# Altium schematic sheet Sheet2.SchDoc
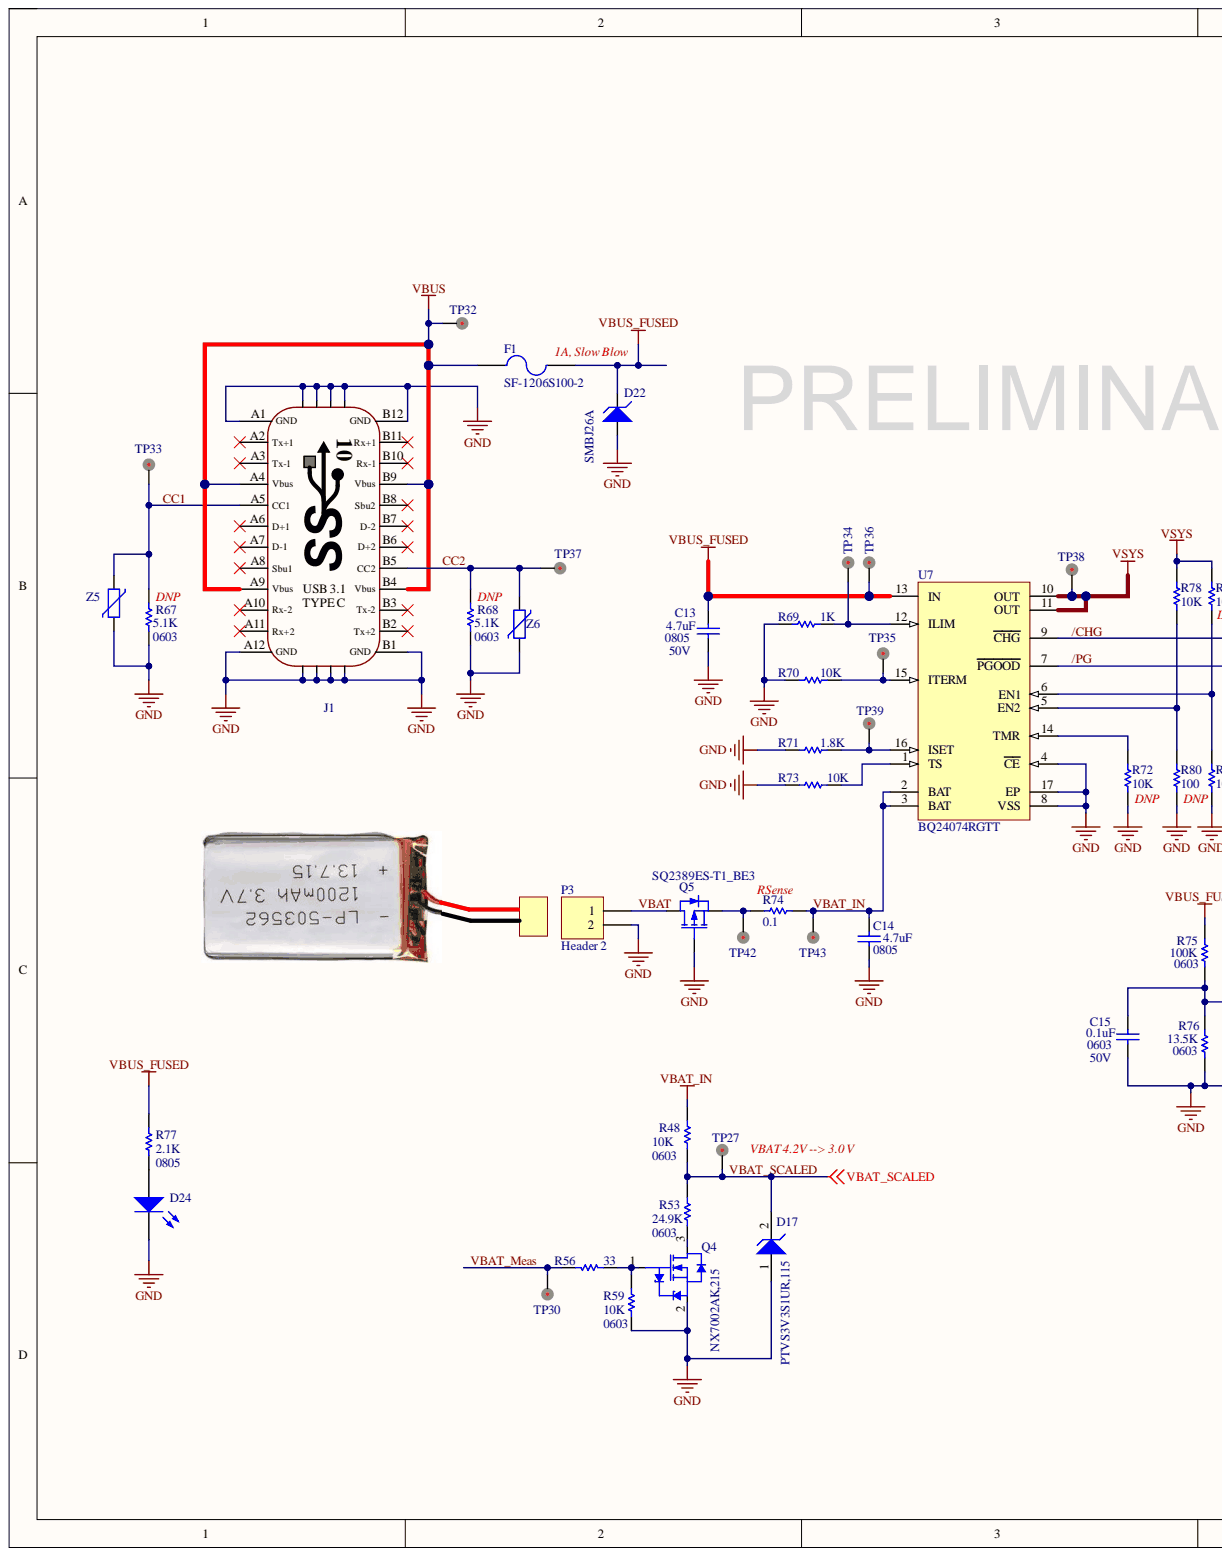
[diagram p0: Sheet2.SchDoc, left]
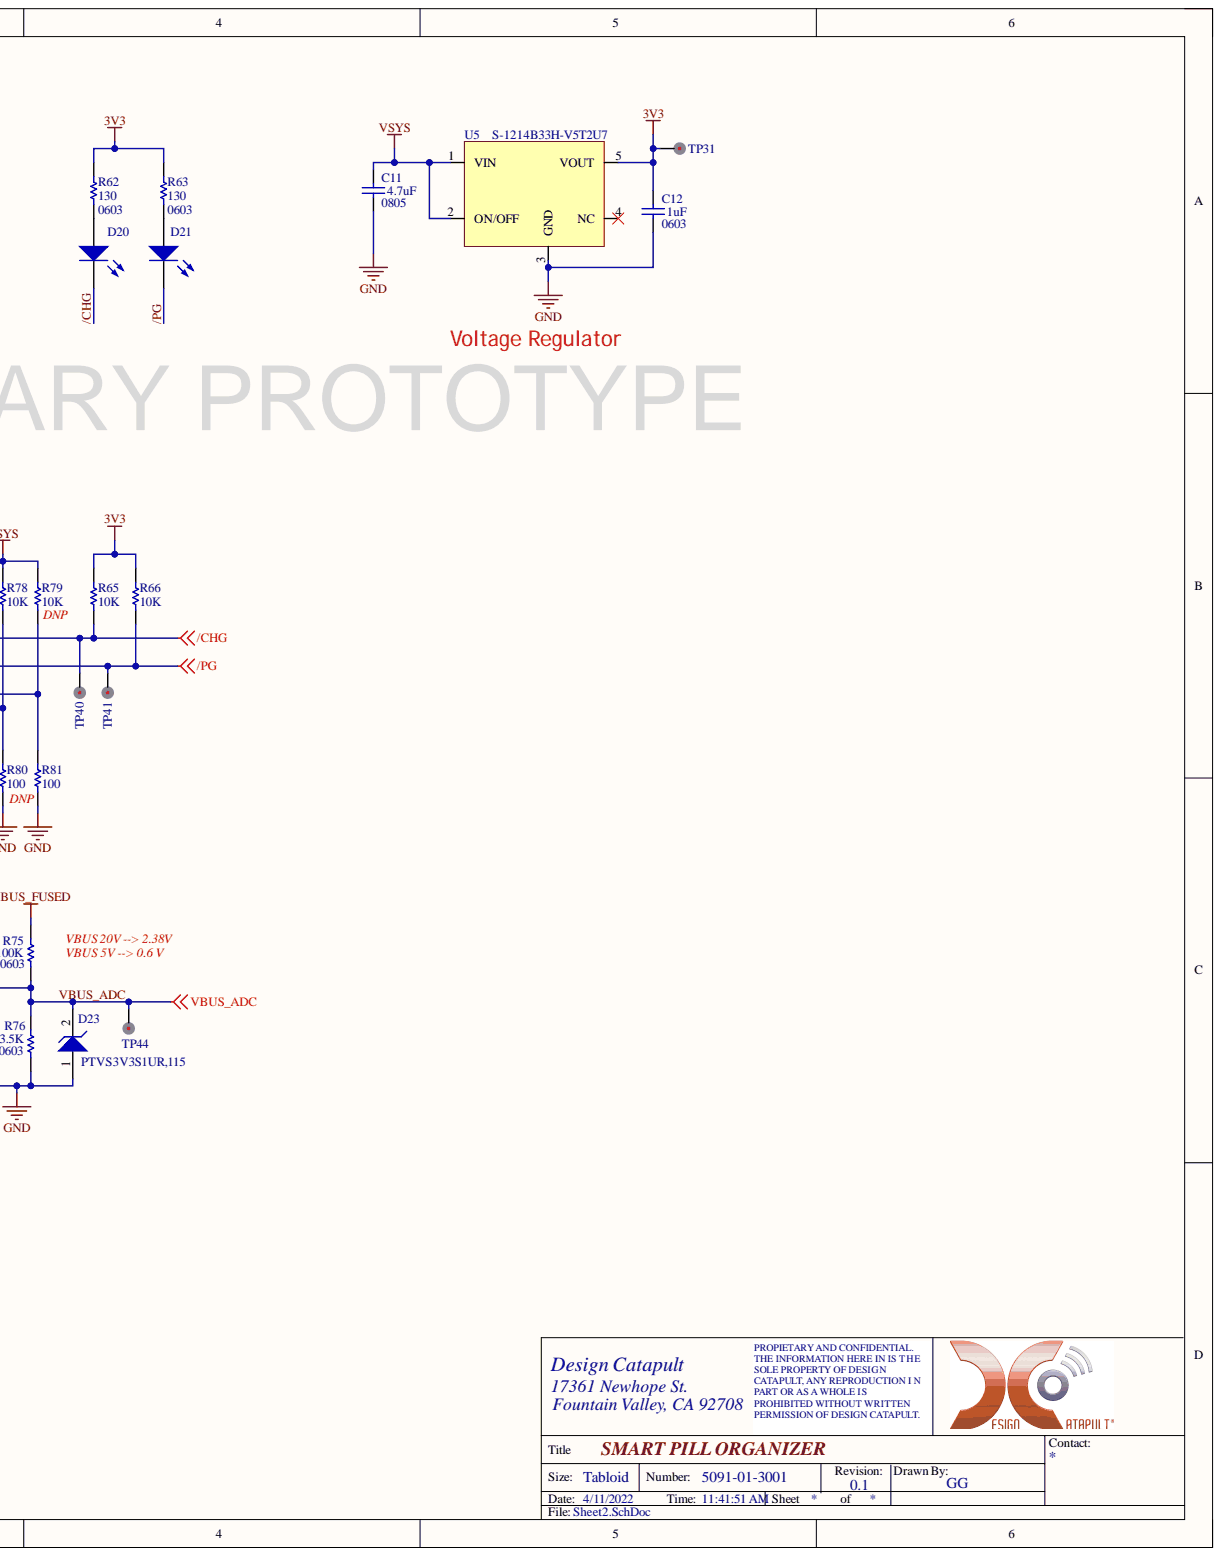
[diagram p1: Sheet2.SchDoc, right]

## Parts
C11  value 4.7uF  Package: 0805  [CAPC2012M]
C12  value 1uF  Package: 0603  [0603C]
C13  value 4.7uF  Package: 0805  Voltage: 50V  [CAPC2012M]
C14  4.7uF  Package: 0805  [CAPC2012M]
C15  0.1uF  Package: 0603  Voltage: 50V  [CAPC1608X90N]
D17  PTVS3V3S1UR,115  [SOD123]
D20  LED0603  [LED-0603-GREEN]  (value not drawn)
D21  LED0603  [LED-0603-GREEN]  (value not drawn)
D22  SMBJ26A  [VISH-DO-214AA-A-2_V]
D23  PTVS3V3S1UR,115  [SOD123]
D24  LED0603  [LED-0603-GREEN]  (value not drawn)
F1  SF-1206S100-2  [FUSE1206]
J1  USB_3.1_TypeC_Receptacle_1.6mm  [USB3 C - SMT ET T - H - F - WURTH - 632723X00011]  (value not drawn)
P3  Header 2  [HDR1X2]
Q4  NX7002AK,215  [SOT23-3N]
Q5  SQ2389ES-T1_BE3  [SOT23-3N]
R48  10K  Package: 0603  [0603R]
R53  24.9K  Package: 0603  [0603R]
R56  33  [0603R]
R59  10K  Package: 0603  [0603R]
R62  130  Package: 0603  [0603R]
R63  130  Package: 0603  [0603R]
R65  10K  [0603R]
R66  10K  [0603R]
R67  5.1K  Package: 0603  [0603R]
R68  5.1K  Package: 0603  [0603R]
R69  1K  [0603R]
R70  10K  [0603R]
R71  1.8K  [0603R]
R72  10K  [0603R]
R73  10K  [0603R]
R74  0.1  [RESC2012X08N]
R75  100K  Package: 0603  [0603R]
R76  13.5K  Package: 0603  [0603R]
R77  2.1K  [RESC2012X08N]
R78  10K  [0603R]
R79  10K  [0603R]
R80  100  [0603R]
R81  100  [0603R]
TP27  Pogo Pin  [TP-TH-PogoPin]  (value not drawn)
TP30  Pogo Pin  [TP-TH-PogoPin]  (value not drawn)
TP31  Pogo Pin  [TP-TH-PogoPin]  (value not drawn)
TP32  Pogo Pin  [TP-TH-PogoPin]  (value not drawn)
TP33  Pogo Pin  [TP-TH-PogoPin]  (value not drawn)
TP34  Pogo Pin  [TP-TH-PogoPin]  (value not drawn)
TP35  Pogo Pin  [TP-TH-PogoPin]  (value not drawn)
TP36  Pogo Pin  [TP-TH-PogoPin]  (value not drawn)
TP37  Pogo Pin  [TP-TH-PogoPin]  (value not drawn)
TP38  Pogo Pin  [TP-TH-PogoPin]  (value not drawn)
TP39  Pogo Pin  [TP-TH-PogoPin]  (value not drawn)
TP40  Pogo Pin  [TP-TH-PogoPin]  (value not drawn)
TP41  Pogo Pin  [TP-TH-PogoPin]  (value not drawn)
TP42  Pogo Pin  [TP-TH-PogoPin]  (value not drawn)
TP43  Pogo Pin  [TP-TH-PogoPin]  (value not drawn)
TP44  Pogo Pin  [TP-TH-PogoPin]  (value not drawn)
U5  S-1214B33H-V5T2U7  [TO-252-5SA]
U7  BQ24074RGTT  [16QFN]
Z5  CG0603MLC-05E  [0603F]  (value not drawn)
Z6  CG0603MLC-05E  [0603F]  (value not drawn)

## Nets
3V3: C12.2 R62.1 R63.1 R65.1 R66.1 TP31.1 U5.5(VOUT)
GND: C11.1 C12.1 C13.1 C14.2 C15.1 D17.1 D22.1 D23.1 D24.2 J1.A1(GND) J1.A12(GND) J1.B1(GND) J1.B12(GND) J1.G1 J1.G2 J1.G3 J1.G4 J1.G5 J1.G6 J1.G7 J1.G8 P3.2 Q4.2 Q5.1 R59.2 R67.1 R68.1 R69.1 R70.2 R71.2 R72.2 R73.2 R76.2 R80.2 R81.2 U5.3(GND) U7.4(CE) U7.8(VSS) U7.17(EP) Z5.1 Z6.1
VBUS: F1.1 J1.A4(Vbus) J1.A9(Vbus) J1.B4(Vbus) J1.B9(Vbus) TP32.1
VBUS_FUSED: C13.2 D22.2 F1.2 R75.1 R77.2 TP36.1 U7.13(IN)
VSYS: C11.2 R78.1 R79.1 TP38.1 U5.1(VIN) U5.2(ON/OFF) U7.10(OUT) U7.11(OUT)
/CHG: D20.2 R65.2 TP40.1 U7.9(CHG)
/PG: D21.2 R66.2 TP41.1 U7.7(PGOOD)
CC1: J1.A5(CC1) R67.2 TP33.1 Z5.2
CC2: J1.B5(CC2) R68.2 TP37.1 Z6.2
VBAT: P3.1 Q5.3
VBAT_IN: C14.1 R48.1 R74.1 TP43.1 U7.2(BAT) U7.3(BAT)
VBAT_Meas: R56.1 TP30.1
VBAT_SCALED: D17.2 R48.2 R53.1 TP27.1
VBUS_ADC: C15.2 D23.2 R75.2 R76.1 TP44.1
NetD20_1: D20.1 R62.2
NetD21_1: D21.1 R63.2
NetD24_1: D24.1 R77.1
NetQ4_1: Q4.1 R56.2 R59.1
NetQ4_3: Q4.3 R53.2
NetQ5_2: Q5.2 R74.2 TP42.1
NetR69_2: R69.2 TP34.1 U7.12(ILIM)
NetR70_1: R70.1 TP35.1 U7.15(ITERM)
NetR71_1: R71.1 TP39.1 U7.16(ISET)
NetR72_1: R72.1 U7.14(TMR)
NetR73_1: R73.1 U7.1(TS)
NetR78_2: R78.2 R80.1 U7.5(EN2)
NetR79_2: R79.2 R81.1 U7.6(EN1)

Not connected: J1 A2(Tx+1) A3(Tx-1) A6(D+1) A7(D-1) A8(Sbu1) A10(Rx-2) A11(Rx+2) B2(Tx+2) B3(Tx-2) B6(D+2) B7(D-2) B8(Sbu2) B10(Rx-1) B11(Rx+1); U5 4(NC)

## Library data of the parts
C11 C13 = CMP-2007-04610-1: CAP CER 4.7UF 50V X7R 0805; Manufacturer: TDK Corporation; Manufacturer Part Number: CGA4J1X7R1H475K125AC; Component Kind: Standard; Library Name: 3461-03-3104.PcbLib; Part Number: CGA4J1X7R1H475K125AC
  C11: Voltage: 50V
C12 = CMP-2007-04610-1: CAP CER 1UF 50V X5R 0603; Component Kind: Standard; Library Name: 3461-03-3104.PcbLib; Voltage: 50V
C14 = Cap: Capacitor; Component Kind: Standard; Library Name: Miscellaneous Devices.IntLib; Value: 4.7uF
C15 = Cap: CAP CER 0.1UF 50V X7R 0603; Component Kind: Standard; Library Name: Miscellaneous Devices.IntLib; Value: 0.1uF
D17 D23 = TVS-5V: Silicon Zener Diode; Manufacturer: Nexperia USA Inc.; Current - Peak Pulse (10/1000µs): 43.5A; Power - Peak Pulse: 400W; Power Line Protection: No; Voltage - Breakdown (Min): 6.4V; Voltage - Clamping (Max) @ Ipp: 9.2V; Voltage - Reverse Standoff (Typ): 5V; Applications: Automotive; Capacitance @ Frequency: -; Category: Circuit Protection; Component Kind: Standard; ComponentLink1Description: http://assets.nexperia.com/documents/data-sheet/PTVSXS1UR_SER.pdf; ComponentLink1URL: http://assets.nexperia.com/documents/data-sheet/PTVSXS1UR_SER.pdf; Manufacturer Part Number: PTVS3V3S1UR,115; Mounting Type: Surface Mount; Operating Temperature: -55°C ~ 150°C (TA); Package / Case: SOD-123W; Packaging: Cut Tape (CT); Part Status: Active; Pricing 1: 1=0.44, 10=0.329, 100=0.2051, 500=0.14032, 1000=0.10794 (USD); RoHS: RoHS Compliant; Signal Integrity: DL5262B; Simulation: DL5262B; Stock 1: 4643; Supplier 1: Digi-Key; Supplier Device Package: CFP3; Supplier Part Number 1: 1727-5229-1-ND; Type: Zener; Library Name: DesignCatapultLib.SchLib
D20 D21 D24 = LED0603: LED GREEN CLEAR CHIP SMD 0603; Component Kind: Standard; LatestRevisionDate: 08-Jul-2005; LatestRevisionNote: Mech Layer 1 removed.; PackageDescription: LED; 2 Leads; PackageReference: LED-0; Published: 8-Jun-2000; Simulation: LED0; Library Name: DesignCatapultLib.SchLib; Publisher: Altium Limited
D22 = Diode TVS Unipolar: TVS DIODE 26V 42.1V DO214AA 42.1V Clamp 14.3A Ipp Tvs Diode Surface Mount DO-214AA (SMBJ); Manufacturer: Bourns Inc; Breakdown Voltage Max: 28.9V; Breakdown Voltage Min: 26.7V; Clamping Voltage Vc Max: 38.9V; No. of Pins: 2Pins; Peak Pulse Current Ippm: 14A; Peak Pulse Power Dissipation: 600W; Product Range: TRANSZORBSMBJSeries; SVHC: ToBeAdvised; TVS Polarity: Unidirectional; Component Kind: Standard; Datasheet URL: https://www.littelfuse.com/~/media/electronics/datasheets/tvs_diodes/littelfuse_tvs_diode_smbj_datasheet.pdf.pdf; Datasheet Version: 02/2014; Diode Case Style: DO-214AA; Manufacturer Part Number: SMBJ26A; Manufacturer URL: http://www.vishay.com/; Mounting Technology: SurfaceMount; Package Description: 2-Pin Surface Mount Device, Body 4.315 x 3.62 mm; Package Reference: DO-214AA; Packaging: CutTape; Power Dissipation Pd: 600W; Reverse Stand-Off Voltage Vrwm: 26V; Library Name: DesignCatapultLib.SchLib
F1 = Fuse SMD: PTC RESET FUSE 24V 500MA 1206; Manufacturer: Bourns Inc; Approvals: PSE, UL; Breaking Capacity @ Rated Voltage: 50A; Fuse Type: Board Mount (Cartridge Style Excluded); Melting I²t: 0.53; Response Time: Fast; Voltage Rating - AC: 125V; Category: Circuit Protection; Color: -; Component Kind: Standard; ComponentLink1Description: http://www.littelfuse.com/~/media/electronics/datasheets/fuses/littelfuse_fuse_154_154t_154l_154tl_datasheet.pdf.pdf; ComponentLink1URL: http://www.littelfuse.com/~/media/electronics/datasheets/fuses/littelfuse_fuse_154_154t_154l_154tl_datasheet.pdf.pdf; LatestRevisionDate: 17-Jul-2002; LatestRevisionNote: Re-released for DXP Platform.; Manufacturer Part Number: SF-1206S100-2; Mounting Type: Holder/Surface Mount; Operating Temperature: -55°C ~ 125°C; Package / Case: 2-SMD, Square End Block with Holder; PackageDescription: Thru-Hole; 2 Leads; PackageReference: PIN-W2/E2.8; Packaging: Digi-Reel®; Part Status: Active; Pricing 1: 1=2.55, 5=2.432, 10=2.31, 50=1.8646, 100=1.5809, 250=1.54032, 500=1.29712 (USD); Published: 8-Jun-2000; RoHS: RoHS Compliant; Simulation: FUSE; Size / Dimension: 0.383" L x 0.198" W x 0.150" H (9.73mm x 5.03mm x 3.81mm); Stock 1: 12495; Supplier 1: Digi-Key; Supplier Part Number 1: F1224DKR-ND; Voltage Rating - DC: 125V; Library Name: DesignCatapultLib.SchLib; Publisher: Altium Limited
J1 = USB_3.1_TypeC_Receptacle_1.6mm: USB 3.1 Type C Receptacle Horizontal THR WR-COM 1.6mm PCB thickness; Manufacturer: Würth Elektronik; Manufacturer’s ordering code: 632723300011; Component Kind: Standard; Order Code Digikey: 732-9618-1-ND; Order Code Mouser: 710-632723300011; Part Number: 632723300011; Library Name: MannySchlib1.SchLib
P3 = Header 2: Header, 2-Pin; Component Kind: Standard; LatestRevisionDate: 17-Jul-2002; LatestRevisionNote: Re-released for DXP Platform.; Library Name: Miscellaneous Connectors.IntLib; Signal Integrity: Connector; Publisher: Altium Limited
Q4 = NX7002AK,215: Single N-Channel Trench MOSFET, 60 V, -55 to 150 degC, 3-Pin SOT23, Tape and Reel; Manufacturer: Nexperia USA Inc.; Channel Type: N; Ciss [typ] (pF): 11; Coss [typ] (pF): 3.4; Packing: Tape & Reel; VDS [max] (V): 60; VGSth [typ] (V): 1.6; Code_JEDEC: TO-236AB; Component Kind: Standard; ComponentLink1Description: Manufacturer URL; ComponentLink1URL: http://www.nxp.com/; ComponentLink2Description: Datasheet; ComponentLink2URL: http://www.nxp.com/documents/data_sheet/NX7002AK.pdf; ComponentLink3Description: Package Specification; ComponentLink3URL: http://www.nxp.com/documents/outline_drawing/sot023_po.pdf; DatasheetVersion: Rev. 5; Manufacturer Part Number: NX7002AK,215; Mounting Technology: Surface Mount; PackageDescription: 3-Pin Small Outline Transistor Package, Body 2.9 x 1.3 mm, Pitch 0.95 mm; PackageReference: SOT23; PackageVersion: 06-03-16, 03/2006; PartNumber: NX7002AK,215; Library Name: DesignCatapultLib.SchLib
Q5 = MOSFET-P_DIODE: MOSFET P-CH 40V 4.1A SOT23-3; Manufacturer: Vishay; Component Kind: Standard; LatestRevisionDate: 17-Jul-2002; LatestRevisionNote: Re-released for DXP Platform.; Manufacturer Part Number: SQ2389ES-T1_BE3; PackageDescription: TO, Flat Index; 3 In-Line, Axial Leads; Body Dia. 4.6mm; Lead Dia. 0.5 mm (max); PackageReference: E3; PackageVersion: 1995/1996; Published: 8-Jun-2000; Simulation: PMOS; Library Name: DesignCatapultLib.SchLib; Publisher: Altium Limited
R48 = Res1: RES SMD 10K OHM 1% 1/10W 0603; Component Kind: Standard; Library Name: Miscellaneous Devices.IntLib; Value: 10K
R53 = Res1: RES SMD 24.9K OHM 1% 1/10W 0603; Component Kind: Standard; Library Name: Miscellaneous Devices.IntLib; Value: 24.9K
R56 = Res1: RES SMD 33 OHM 1% 1/10W 0603; DSPM Part Number: 003-0404-00; Component Kind: Standard; Library Name: Miscellaneous Devices.IntLib; Published: 8-Jun-2000; Revision: July-2002: Re-released for DXP Platform.; Signal Integrity: Res; Simulation: RESISTOR; Value: 33; Publisher: Altium Limited
R59 R65 R66 R70 R72 R73 R78 R79 = Res1: RES SMD 10K OHM 1% 1/10W 0603; Manufacturer: VISHAY Beyschlag; Component Kind: Standard; Library Name: Miscellaneous Devices.IntLib; Value: 10K
  R65 R66 R70 R72 R73 R78 R79: Package: 0603
R62 R63 = Res1: RES 130 OHM 1% 1/10W 0603; Manufacturer: Yageo; Component Kind: Standard; Library Name: Miscellaneous Devices.IntLib; Value: 130
R67 R68 = Res1: RES SMD 5.1K OHM 1% 1/10W 0603; Manufacturer: VISHAY Beyschlag; Component Kind: Standard; Library Name: Miscellaneous Devices.IntLib; Value: 5.1K
R69 = Res1: RES SMD 1K OHM 1% 1/10W 0603; Manufacturer: VISHAY Beyschlag; Component Kind: Standard; Library Name: Miscellaneous Devices.IntLib; Package: 0603; Value: 1K
R71 = Res1: RES SMD 1.8K OHM 1% 1/10W 0603; Manufacturer: VISHAY Beyschlag; Component Kind: Standard; Library Name: Miscellaneous Devices.IntLib; Package: 0603; Value: 1.8K
R74 = Res1: RES 0.1 OHM 1% 1/8W 0805; Manufacturer: Yageo; Component Kind: Standard; Library Name: Miscellaneous Devices.IntLib; Package: 0805; Value: 0.1
R75 = Res1: RES SMD 100K OHM 1% 1/10W 0603; Manufacturer: VISHAY Beyschlag; Component Kind: Standard; Library Name: Miscellaneous Devices.IntLib; Value: 100K
R76 = Res1: RES SMD 13.5K OHM 1% 1/10W 0603; Manufacturer: VISHAY Beyschlag; Component Kind: Standard; Library Name: Miscellaneous Devices.IntLib; Value: 13.5K
R77 = res1: RES SMD 2.1K OHM 1/4W 0805; DSPM Part Number: 003-0408-00; Component Kind: Standard; Library Name: Miscellaneous Devices.IntLib; Package: 0805; Revision: July-2002: Re-released for DXP Platform.; Signal Integrity: Res; Simulation: RESISTOR; Value: 2.1K
R80 R81 = Res1: RES SMD 100 OHM 1% 1/10W 0603; Manufacturer: VISHAY Beyschlag; Component Kind: Standard; Library Name: Miscellaneous Devices.IntLib; Package: 0603; Value: 100
TP27 TP30-TP44 = TEST POINT POGO: Test Point 150mil Hole 65mil; Manufacturer Part Number: P13-4023; Component Kind: Standard; Part Number2: P13-0123; Part Number3: P13-1123; Part Number4: P13-2123; Library Name: DesignCatapultLib.SchLib
U5 = S-1214B33H: Component Kind: Standard; Manufacturer Part Number: S-1214B33H-V5T2U7; Library Name: DesignCatapultLib.SchLib
U7 = BQ24074: USB-Friendly Li-Ion Battery Charger and Power-Path Management IC, 10.5 V OVP, 4.3 V, -40 to 85 degC, 16-pin QFN (RGT), Green (RoHS & no Sb/Br); Manufacturer: Texas Instruments; Lead Acid: N; SubFamily: Battery Charge Management; Vin(Min)(V): 4.3; Code_JEDEC: MO-220; Component Kind: Standard; ComponentLink1Description: Datasheet; ComponentLink1URL: http://www.ti.com/general/docs/lit/getliterature.tsp?genericPartNumber=BQ24074&fileType=pdf; DatasheetVersion: SLUS810G; Eco-Plan: Green (RoHS & no Sb/Br); Li-Ion: N; Li-Polymer: N; Mounting Technology: Surface Mount; NiCd: N; NiMH: N; Over Voltage Protection: N; PackageDescription: 16-Pin Plastic Quad Flat No-Lead, 3 x 3 mm Body, 0.5 mm Pitch, 1.7 x 1.7 mm Exposed Pad; PackageReference: RGT0016C; PackageVersion: revH, Oct-2011; PartNumber: BQ24074RGTT; Library Name: DesignCatapultLib.SchLib
Z5 Z6 = VARISTORCN0603: Varistor 1 Circuit Surface Mount, MLCV 0603 (1608 Metric); Manufacturer: Bourns Inc.; Component Kind: Standard; Manufacturer Part Number: CG0603MLC-05E; Library Name: DesignCatapultLib.SchLib
